# Altium schematic sheet Serial Monitor Schematic.SchDoc("Serial Monitor Schematic")
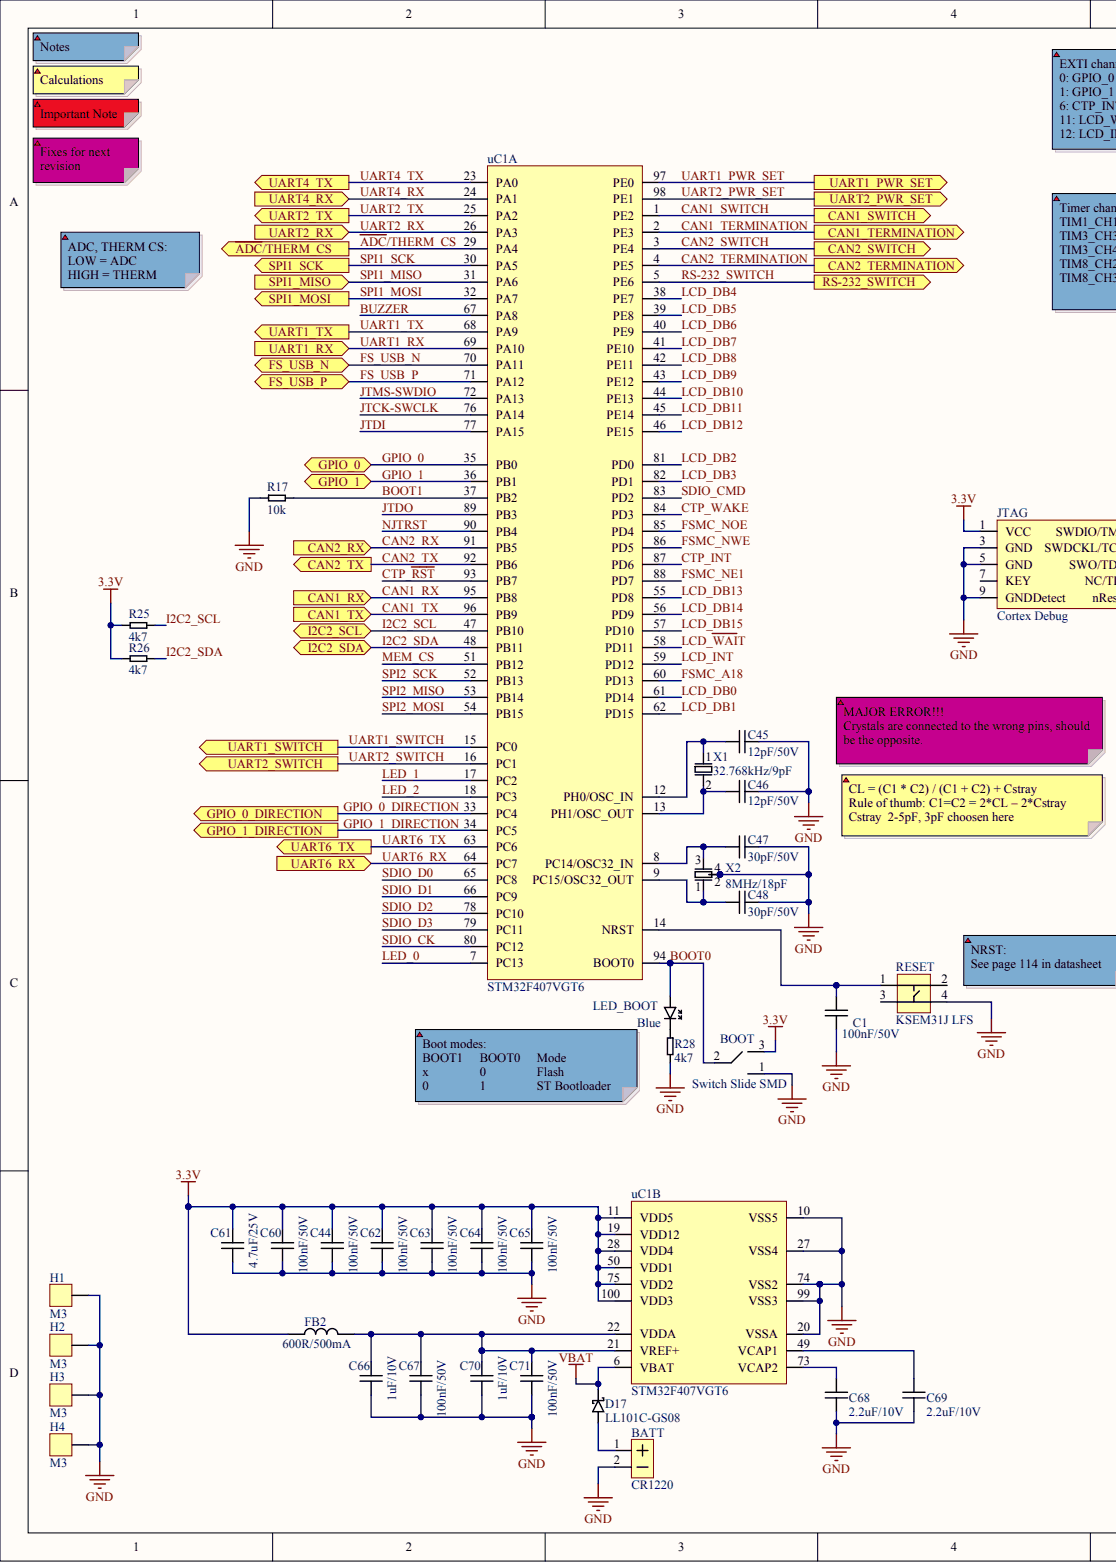
[diagram p0: Serial Monitor Schematic.SchDoc, left]
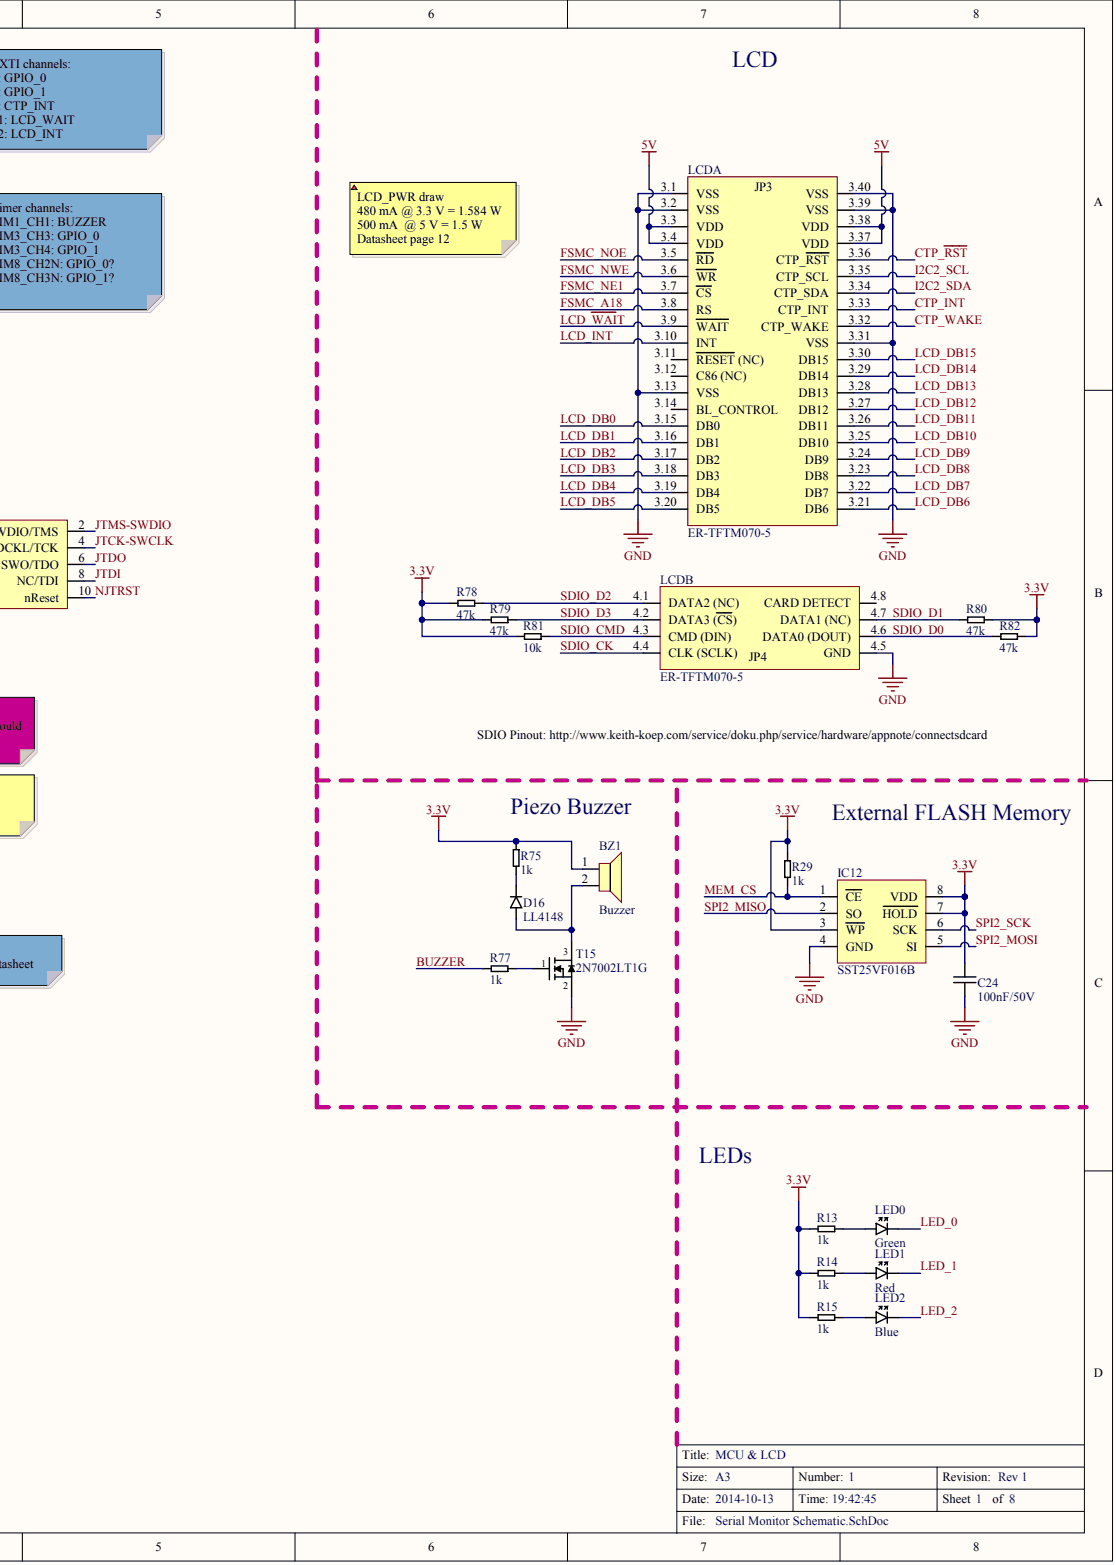
[diagram p1: Serial Monitor Schematic.SchDoc, right]

## Parts
BATT  value CR1220  (hidden comment: Coin Cell Holder CR1220)
BOOT  Switch Slide SMD
BZ1  value Buzzer  (hidden comment: Piezo Buzzer 12mm 5V)
C1  value 100nF/50V  [0603]  (hidden comment: Cap_0603_100nF/50V)
C24  value 100nF/50V  [0603]  (hidden comment: Cap_0603_100nF/50V)
C44  value 100nF/50V  [0603]  (hidden comment: Cap_0603_100nF/50V)
C45  value 12pF/50V  [0603]  (hidden comment: Cap_0603_12pF/50V)
C46  value 12pF/50V  [0603]  (hidden comment: Cap_0603_12pF/50V)
C47  value 30pF/50V  [0603]  (hidden comment: Cap_0603_30pF/50V)
C48  value 30pF/50V  [0603]  (hidden comment: Cap_0603_30pF/50V)
C60  Cap_0603_100nF/50V  [0603]  (value not drawn)
C61  value 4.7uF/25V  [0805]  (hidden comment: Cap_0805_4.7uF/25V)
C62  value 100nF/50V  [0603]  (hidden comment: Cap_0603_100nF/50V)
C63  value 100nF/50V  [0603]  (hidden comment: Cap_0603_100nF/50V)
C64  value 100nF/50V  [0603]  (hidden comment: Cap_0603_100nF/50V)
C65  value 100nF/50V  [0603]  (hidden comment: Cap_0603_100nF/50V)
C66  value 1uF/10V  [0603]  (hidden comment: Cap_0603_1uF/10V)
C67  value 100nF/50V  [0603]  (hidden comment: Cap_0603_100nF/50V)
C68  value 2.2uF/10V  [0805]  (hidden comment: Cap_0805_2.2uF/10V)
C69  value 2.2uF/10V  [0805]  (hidden comment: Cap_0805_2.2uF/10V)
C70  value 1uF/10V  [0603]  (hidden comment: Cap_0603_1uF/10V)
C71  value 100nF/50V  [0603]  (hidden comment: Cap_0603_100nF/50V)
D16  LL4148
D17  LL101C-GS08
FB2  value 600R/500mA  [0603]  (hidden comment: Ferrite_Bead_0603_600R_500mA)
H1  value M3  (hidden comment: M3_SCREWHOLE)
H2  value M3  (hidden comment: M3_SCREWHOLE)
H3  value M3  (hidden comment: M3_SCREWHOLE)
H4  value M3  (hidden comment: M3_SCREWHOLE)
IC12  SST25VF016B
JTAG  value Cortex Debug  (hidden comment: Connector 2x5 Cortex Debug)
LCD  value ER-TFTM070-5  Part No.: ER-TFTM070-5  (hidden comment: LCD ER-TFTM070-5 (w/o Keypad))  (parts A,B)
LED0  value Green  (hidden comment: LED_0603_Green)
LED1  value Red  (hidden comment: LED_0603_Red)
LED2  value Blue  (hidden comment: LED_0603_Blue)
LED_BOOT  value Blue  (hidden comment: LED_0603_Blue)
R13  value 1k  [0603]  (hidden comment: Res_0603_1k)
R14  value 1k  [0603]  (hidden comment: Res_0603_1k)
R15  value 1k  [0603]  (hidden comment: Res_0603_1k)
R17  value 10k  [0603]  (hidden comment: Res_0603_10k)
R25  value 4k7  [0603]  (hidden comment: Res_0603_4k7)
R26  value 4k7  [0603]  (hidden comment: Res_0603_4k7)
R28  value 4k7  [0603]  (hidden comment: Res_0603_4k7)
R29  value 1k  [0603]  (hidden comment: Res_0603_1k)
R75  value 1k  [0603]  (hidden comment: Res_0603_1k)
R77  value 1k  [0603]  (hidden comment: Res_0603_1k)
R78  value 47k  [0603]  (hidden comment: Res_0603_47k)
R79  value 47k  [0603]  (hidden comment: Res_0603_47k)
R80  value 47k  [0603]  (hidden comment: Res_0603_47k)
R81  value 10k  [0603]  (hidden comment: Res_0603_10k)
R82  value 47k  [0603]  (hidden comment: Res_0603_47k)
RESET  value KSEM31J LFS  Part No.: KSEM31J LFS  (hidden comment: Tact Switch KSEM31J LFS)
T15  2N7002LT1G  [SOT-23]
uC1  STM32F407VGT6  [LQFP-100]  (parts A,B)
X1  value 32.768kHz/9pF  [2-SMD 3.5x1.5mm]  (hidden comment: ABS07-32.768KHZ-9-T)
X2  value 8MHz/18pF  [4-pin SMD 5x3mm]  (hidden comment: 7B-8.000MAAJ-T)

## Ports
CAN1_RX, CAN1_SWITCH, CAN1_TERMINATION, CAN1_TX, CAN2_RX, CAN2_SWITCH, CAN2_TERMINATION, CAN2_TX, FS_USB_N, FS_USB_P, GPIO_0, GPIO_0_DIRECTION, GPIO_1, GPIO_1_DIRECTION, I2C2_SCL, I2C2_SDA, RS-232_SWITCH, SPI1_MISO, SPI1_MOSI, SPI1_SCK, UART1_PWR_SET, UART1_RX, UART1_SWITCH, UART1_TX, UART2_PWR_SET, UART2_RX, UART2_SWITCH, UART2_TX, UART4_RX, UART4_TX, UART6_RX, UART6_TX, ~{ADC}/THERM_CS

## Nets
3.3V: BOOT.3 BZ1.1 C24.2 C44.2 C60.2 C61.2 C62.2 C63.2 C64.2 C65.2 FB2.1 IC12.3(WP) IC12.7(HOLD) IC12.8(VDD) JTAG.1(VCC) R13.1 R14.1 R15.1 R25.1 R26.1 R29.2 R75.2 R78.1 R79.1 R80.2 R81.1 R82.2 uC1.11(VDD5) uC1.19(VDD12) uC1.28(VDD4) uC1.50(VDD1) uC1.75(VDD2) uC1.100(VDD3)
5V: LCD.3.3(VDD) LCD.3.4(VDD) LCD.3.37(VDD) LCD.3.38(VDD)
GND: BATT.2 BOOT.1 C1.1 C24.1 C44.1 C45.2 C46.2 C47.2 C48.2 C60.1 C61.1 C62.1 C63.1 C64.1 C65.1 C66.1 C67.1 C68.1 C69.1 C70.1 C71.1 H1.HOLE H2.HOLE H3.HOLE H4.HOLE IC12.4(GND) JTAG.3(GND) JTAG.5(GND) JTAG.9(GNDDetect) LCD.3.1(VSS) LCD.3.2(VSS) LCD.3.13(VSS) LCD.3.31(VSS) LCD.3.39(VSS) LCD.3.40(VSS) LCD.4.5(GND) R17.2 R28.1 RESET.4 T15.2 uC1.10(VSS5) uC1.20(VSSA) uC1.27(VSS4) uC1.74(VSS2) uC1.99(VSS3) X2.2 X2.4
VBAT: D17.1 uC1.6(VBAT)
~{ADC}/THERM_CS: uC1.29(PA4) ; port ~{ADC}/THERM_CS
BOOT0: BOOT.2 LED_BOOT.ANODE uC1.94(BOOT0)
BOOT1: R17.1 uC1.37(PB2)
BUZZER: R77.1 uC1.67(PA8)
CAN1_RX: uC1.95(PB8) ; port CAN1_RX
CAN1_SWITCH: uC1.1(PE2) ; port CAN1_SWITCH
CAN1_TERMINATION: uC1.2(PE3) ; port CAN1_TERMINATION
CAN1_TX: uC1.96(PB9) ; port CAN1_TX
CAN2_RX: uC1.91(PB5) ; port CAN2_RX
CAN2_SWITCH: uC1.3(PE4) ; port CAN2_SWITCH
CAN2_TERMINATION: uC1.4(PE5) ; port CAN2_TERMINATION
CAN2_TX: uC1.92(PB6) ; port CAN2_TX
CTP_INT: LCD.3.33(CTP_INT) uC1.87(PD6)
CTP_~{RST}: LCD.3.36(CTP_RST) uC1.93(PB7)
CTP_WAKE: LCD.3.32(CTP_WAKE) uC1.84(PD3)
FS_USB_N: uC1.70(PA11) ; port FS_USB_N
FS_USB_P: uC1.71(PA12) ; port FS_USB_P
FSMC_A18: LCD.3.8(RS) uC1.60(PD13)
FSMC_NE1: LCD.3.7(CS) uC1.88(PD7)
FSMC_NOE: LCD.3.5(RD) uC1.85(PD4)
FSMC_NWE: LCD.3.6(WR) uC1.86(PD5)
GPIO_0: uC1.35(PB0) ; port GPIO_0
GPIO_0_DIRECTION: uC1.33(PC4) ; port GPIO_0_DIRECTION
GPIO_1: uC1.36(PB1) ; port GPIO_1
GPIO_1_DIRECTION: uC1.34(PC5) ; port GPIO_1_DIRECTION
I2C2_SCL: LCD.3.35(CTP_SCL) R25.2 uC1.47(PB10) ; port I2C2_SCL
I2C2_SDA: LCD.3.34(CTP_SDA) R26.2 uC1.48(PB11) ; port I2C2_SDA
JTCK-SWCLK: JTAG.4(SWDCKL/TCK) uC1.76(PA14)
JTDI: JTAG.8(NC/TDI) uC1.77(PA15)
JTDO: JTAG.6(SWO/TDO) uC1.89(PB3)
JTMS-SWDIO: JTAG.2(SWDIO/TMS) uC1.72(PA13)
LCD_DB0: LCD.3.15(DB0) uC1.61(PD14)
LCD_DB1: LCD.3.16(DB1) uC1.62(PD15)
LCD_DB2: LCD.3.17(DB2) uC1.81(PD0)
LCD_DB3: LCD.3.18(DB3) uC1.82(PD1)
LCD_DB4: LCD.3.19(DB4) uC1.38(PE7)
LCD_DB5: LCD.3.20(DB5) uC1.39(PE8)
LCD_DB6: LCD.3.21(DB6) uC1.40(PE9)
LCD_DB7: LCD.3.22(DB7) uC1.41(PE10)
LCD_DB8: LCD.3.23(DB8) uC1.42(PE11)
LCD_DB9: LCD.3.24(DB9) uC1.43(PE12)
LCD_DB10: LCD.3.25(DB10) uC1.44(PE13)
LCD_DB11: LCD.3.26(DB11) uC1.45(PE14)
LCD_DB12: LCD.3.27(DB12) uC1.46(PE15)
LCD_DB13: LCD.3.28(DB13) uC1.55(PD8)
LCD_DB14: LCD.3.29(DB14) uC1.56(PD9)
LCD_DB15: LCD.3.30(DB15) uC1.57(PD10)
LCD_INT: LCD.3.10(INT) uC1.59(PD12)
LCD_~{WAI}T: LCD.3.9(WAIT) uC1.58(PD11)
LED_0: LED0.CATHODE uC1.7(PC13)
LED_1: LED1.CATHODE uC1.17(PC2)
LED_2: LED2.CATHODE uC1.18(PC3)
MEM_CS: IC12.1(CE) R29.1 uC1.51(PB12)
NJTRST: JTAG.10(nReset) uC1.90(PB4)
RS-232_SWITCH: uC1.5(PE6) ; port RS-232_SWITCH
SDIO_CK: LCD.4.4(CLK_(SCLK)) uC1.80(PC12)
SDIO_CMD: LCD.4.3(CMD_(DIN)) R81.2 uC1.83(PD2)
SDIO_D0: LCD.4.6(DATA0_(DOUT)) R82.1 uC1.65(PC8)
SDIO_D1: LCD.4.7(DATA1_(NC)) R80.1 uC1.66(PC9)
SDIO_D2: LCD.4.1(DATA2_(NC)) R78.2 uC1.78(PC10)
SDIO_D3: LCD.4.2(DATA3_(CS)) R79.2 uC1.79(PC11)
SPI1_MISO: uC1.31(PA6) ; port SPI1_MISO
SPI1_MOSI: uC1.32(PA7) ; port SPI1_MOSI
SPI1_SCK: uC1.30(PA5) ; port SPI1_SCK
SPI2_MISO: IC12.2(SO) uC1.53(PB14)
SPI2_MOSI: IC12.5(SI) uC1.54(PB15)
SPI2_SCK: IC12.6(SCK) uC1.52(PB13)
UART1_PWR_SET: uC1.97(PE0) ; port UART1_PWR_SET
UART1_RX: uC1.69(PA10) ; port UART1_RX
UART1_SWITCH: uC1.15(PC0) ; port UART1_SWITCH
UART1_TX: uC1.68(PA9) ; port UART1_TX
UART2_PWR_SET: uC1.98(PE1) ; port UART2_PWR_SET
UART2_RX: uC1.26(PA3) ; port UART2_RX
UART2_SWITCH: uC1.16(PC1) ; port UART2_SWITCH
UART2_TX: uC1.25(PA2) ; port UART2_TX
UART4_RX: uC1.24(PA1) ; port UART4_RX
UART4_TX: uC1.23(PA0) ; port UART4_TX
UART6_RX: uC1.64(PC7) ; port UART6_RX
UART6_TX: uC1.63(PC6) ; port UART6_TX
NetBATT_1: BATT.1 D17.2
NetBZ1_2: BZ1.2 D16.2 T15.3
NetC1_2: C1.2 RESET.1 uC1.14(NRST)
NetC45_1: C45.1 uC1.12(PH0/OSC_IN) X1.1
NetC46_1: C46.1 uC1.13(PH1/OSC_OUT) X1.2
NetC47_1: C47.1 uC1.8(PC14/OSC32_IN) X2.3
NetC48_1: C48.1 uC1.9(PC15/OSC32_OUT) X2.1
NetC66_2: C66.2 C67.2 C70.2 C71.2 FB2.2 uC1.21(VREF+) uC1.22(VDDA)
NetC68_2: C68.2 uC1.73(VCAP2)
NetC69_2: C69.2 uC1.49(VCAP1)
NetD16_1: D16.1 R75.1
NetLED0_ANODE: LED0.ANODE R13.2
NetLED1_ANODE: LED1.ANODE R14.2
NetLED2_ANODE: LED2.ANODE R15.2
NetLED_BOOT_CATHODE: LED_BOOT.CATHODE R28.2
NetR77_2: R77.2 T15.1

Not connected: JTAG 7(KEY); LCD 3.11(RESET_(NC)) 3.12(C86_(NC)) 3.14(BL_CONTROL) 4.8(CARD_DETECT); RESET 2 3

## Library data of the parts
BATT = (no symbol name): Manufacturer: Harwin; Part No.: S8411-45R; Mouser: 855-S8411-45R; DigiKey: 952-1745-1-ND; Price SEK (No VAT): 8; Datasheet: http://www.harwin.com/include/downloads/drawings/S8411R.PDF; Farnell: 2115306
BZ1 = (no symbol name): Manufacturer: CUI Inc; Part No.: CEM-1203(42); Note: Buy from eBay; DigiKey: CEM-1203(42); Price SEK (No VAT): 10
C66 C70 = (no symbol name): Part No.: C1608X5R1A105K080AC; Manufacturer: TDK; Mouser: 810-C1608X5R1A105K; Price SEK (No VAT): 1; DigiKey: 445-1321-1-ND; Value: 1uF/10V
C68 C69 = (no symbol name): Part No.: VJ0805G225KXQTW1BC; Manufacturer: Vishay; Mouser: 77-VJ0805G225KXQTBC
D16 = (no symbol name): Manufacturer: Fairchild Semiconductor; Mouser: 512-LL4148; DigiKey: LL4148FSCT-ND; Value: LL4148; Price SEK (No VAT): 1; Part No.: LL4148
D17 = (no symbol name): Manufacturer: Vishay; Part No.: LL101C-GS08; Mouser: 625-LL101C; Price SEK (No VAT): 1; DigiKey: LL101C-GS08CT-ND; Value: LL101C-GS08
FB2 = (no symbol name): Part No.: MMZ1608B601C; Manufacturer: TDK; RS: 786-5015; Price SEK (No VAT): 1; Mouser: 810-MMZ1608B601C; DigiKey: 445-2166-1-ND; Farnell: 1669687
IC12 = (no symbol name): Part No.: SST25VF016B-75-4I-S2AF; Manufacturer: Microchip; DigiKey: SST25VF016B-75-4I-S2AF; Datasheet: http://www.microchip.com/mymicrochip/filehandler.aspx?ddocname=en550401; Mouser: 579-25VF016B7IS2AF; Value: SST25VF016B; Price SEK (No VAT): 14
LCD = (no symbol name): Manufacturer: EastRising; Mouser: *; DigiKey: *; Price SEK (No VAT): 400; Datasheet: http://www.buydisplay.com/download/manual/ER-TFTM070-5_Datasheet.pdf
R25 = (no symbol name): Value: 4k7; Price (No VAT): 0,1; : *
RESET = (no symbol name): Manufacturer: C&K Components; Mouser: KSEM31J LFS; DigiKey: KSEM31J LFS; Price SEK (No VAT): 3; Datasheet: http://www.ck-components.com/70740/kse_1may12.pdf/
T15 = (no symbol name): Manufacturer: ON Semiconductor; Mouser: 863-2N7002LT1G; Part No.: 2N7002LT1G; DigiKey: 2N7002LT1GOSCT-ND; Price SEK (No VAT): 2; Value: 2N7002LT1G
uC1 = (no symbol name): Manufacturer: ST; Part No.: STM32F407VGT6; Mouser: 511-STM32F407VGT6; DigiKey: 497-11605-ND; Price SEK (No VAT): 114; Value: STM32F407VGT6
X1 = (no symbol name): Manufacturer: Abracon; Part No.: ABS07-32.768KHZ-9-T; Mouser: 815-ABS07-32.768K9T; DigiKey: 535-9544-1-ND; Price SEK (No VAT): 11
X2 = (no symbol name): Manufacturer: TXC; Part No.: 7B-8.000MAAJ-T; Mouser: 717-7B-8.000MAAJ-T; DigiKey: 887-1452-1-ND; Price SEK (No VAT): 7
BOOT C1 C24 C44-C48 C60-C65 C67 C71 H1-H4 JTAG LED0-LED2 LED_BOOT R13-R15 R17 R26 R28 R29 R75 R77-R82 = (no symbol name)
  C60: Value: 100nF/50V
  C61: Value: 4.7uF/25V
  R13-R15 R17 R29 R75 R77-R82: Price (No VAT): 0,1
  R26 R28: Price (No VAT): 0,1; : *
